# Altium schematic sheet 05-Miscellianous.SchDoc
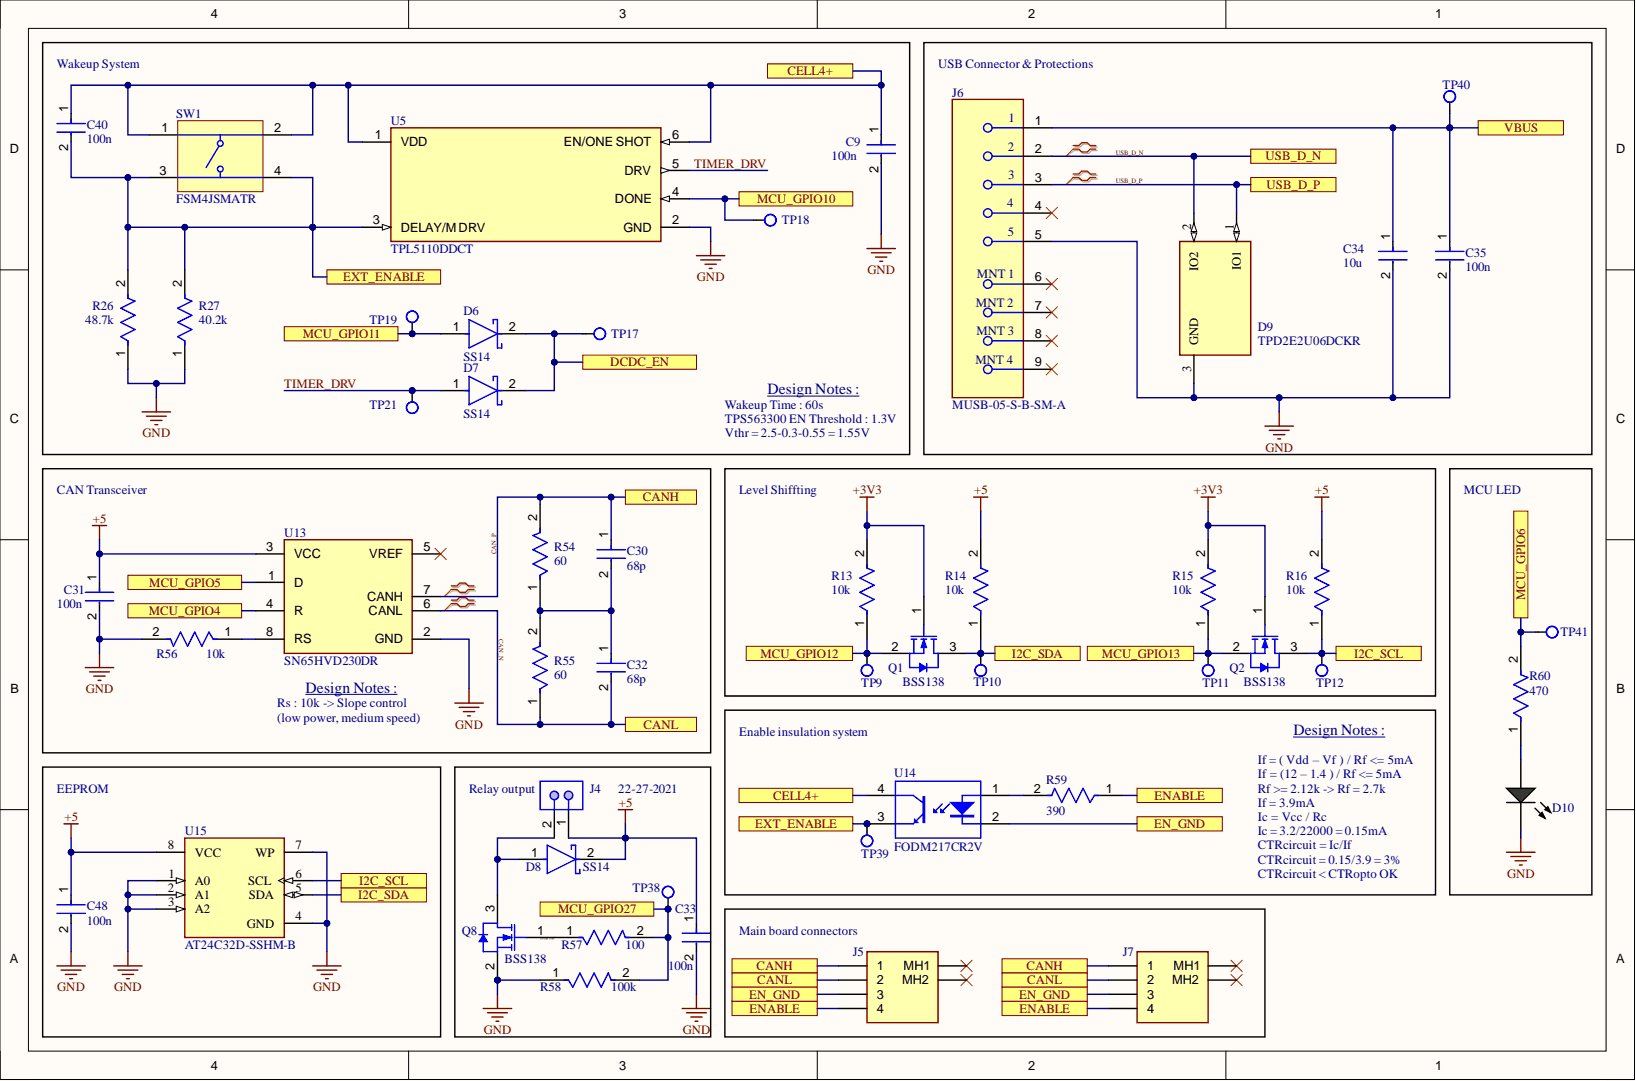
[diagram p0: 05-Miscellianous.SchDoc]

## Parts
C9  100n  [FP-CL21-IPC_A]
C30  68p  [FP-CL21-IPC_A]
C31  100n  [FP-CL21-IPC_A]
C32  68p  [FP-CL21-IPC_A]
C33  100n  [FP-CC0805-0_85-MFG]
C34  10u  [FP-CL21-IPC_A]
C35  100n  [FP-CL21-IPC_A]
C40  100n  [FP-CC0805-0_85-MFG]
C48  100n  [FP-CC0805-0_85-MFG]
D6  SS14  [FP-403AE-MFG]
D7  SS14  [FP-403AE-MFG]
D8  SS14  [FP-403AE-MFG]
D9  TPD2E2U06DCKR  [FP-DCK0003A-IPC_B]
D10  HSMG-C170  [AVAG-HSMX-C170_V]  (value not drawn)
J4  22-27-2021  [MOLX-22-27-2021_V]
J5  1565749-4  [15657494]  (value not drawn)
J6  MUSB-05-S-B-SM-A  [FP-MUSB-05-S-B-SM-A-MFG]
J7  1565749-4  [15657494]  (value not drawn)
Q1  BSS138  [FAIR-SOT23-3_V]
Q2  BSS138  [FAIR-SOT23-3_V]
Q8  BSS138  [FAIR-SOT23-3_V]
R13  10k  [FP-RT0805-MFG]
R14  10k  [FP-RT0805-MFG]
R15  10k  [FP-RT0805-MFG]
R16  10k  [FP-RT0805-MFG]
R26  48.7k  [FP-RT0805-MFG]
R27  40.2k  [FP-RT0805-MFG]
R54  60  [FP-RT0805-MFG]
R55  60  [FP-RT0805-MFG]
R56  10k  [FP-RT0805-MFG]
R57  100  [FP-RT0805-MFG]
R58  100k  [FP-RT0805-MFG]
R59  390  [FP-RT0805-MFG]
R60  470  [FP-RT0805-MFG]
SW1  FSM4JSMATR  [TECO-FSM4JSMATR_V]
TP9  Test point  [Test point-Footprint-1]  (value not drawn)
TP10  Test point  [Test point-Footprint-1]  (value not drawn)
TP11  Test point  [Test point-Footprint-1]  (value not drawn)
TP12  Test point  [Test point-Footprint-1]  (value not drawn)
TP17  Test point  [Test point-Footprint-1]  (value not drawn)
TP18  Test point  [Test point-Footprint-1]  (value not drawn)
TP19  Test point  [Test point-Footprint-1]  (value not drawn)
TP21  Test point  [Test point-Footprint-1]  (value not drawn)
TP38  Test point  [Test point-Footprint-1]  (value not drawn)
TP39  Test point  [Test point-Footprint-1]  (value not drawn)
TP40  Test point  [Test point-Footprint-1]  (value not drawn)
TP41  Test point  [Test point-Footprint-1]  (value not drawn)
U5  TPL5110DDCT  [FP-DDC0006A-MFG]
U13  SN65HVD230DR  [FP-D0008A-MFG]
U14  FODM217CR2V  [SOP127P680X239-4N]
U15  AT24C32D-SSHM-B  [FP-C04-057-SN-MFG]

## Ports
CANH, CANL, CELL4+, DCDC_EN, EN_GND, ENABLE, EXT_ENABLE, I2C_SCL, I2C_SDA, MCU_GPIO4, MCU_GPIO5, MCU_GPIO6, MCU_GPIO10, MCU_GPIO11, MCU_GPIO12, MCU_GPIO13, MCU_GPIO27, USB_D_N, USB_D_P, VBUS

## Nets
+3V3: Q1.1 Q2.1 R13.2 R15.2
+5: C31.1 C33.1 C48.1 D8.2 J4.1 R14.2 R16.2 U13.3(VCC) U15.8(VCC)
GND: C9.2 C31.2 C33.2 C34.2 C35.2 C48.2 D9.3 D10.2 J6.5 Q8.2 R26.1 R27.1 R56.2 R58.1 U5.2(GND) U13.2(GND) U15.1(A0) U15.2(A1) U15.3(A2) U15.4(GND) U15.7(WP)
CAN_N: C32.2 J5.2 J7.2 R55.1 U13.6(CANL) ; port CANL
CAN_P: C30.1 J5.1 J7.1 R54.2 U13.7(CANH) ; port CANH
CELL4+: C9.1 C40.1 SW1.1 SW1.2 U5.1(VDD) U5.6(EN/ONE_SHOT) U14.4 ; port CELL4+
DCDC_EN: D6.2 D7.2 TP17.1 ; port DCDC_EN
EN_GND: J5.3 J7.3 U14.2 ; port EN_GND
ENABLE: J5.4 J7.4 R59.1 ; port ENABLE
EXT_ENABLE: C40.2 R26.2 R27.2 SW1.3 SW1.4 TP39.1 U5.3(DELAY/M_DRV) U14.3 ; port EXT_ENABLE
I2C_SCL: Q2.3 R16.1 TP12.1 U15.6(SCL) ; port I2C_SCL
I2C_SDA: Q1.3 R14.1 TP10.1 U15.5(SDA) ; port I2C_SDA
MCU_GPIO4: U13.4(R) ; port MCU_GPIO4
MCU_GPIO5: U13.1(D) ; port MCU_GPIO5
MCU_GPIO6: R60.2 TP41.1 ; port MCU_GPIO6
MCU_GPIO10: TP18.1 U5.4(DONE) ; port MCU_GPIO10
MCU_GPIO11: D6.1 TP19.1 ; port MCU_GPIO11
MCU_GPIO12: Q1.2 R13.1 TP9.1 ; port MCU_GPIO12
MCU_GPIO13: Q2.2 R15.1 TP11.1 ; port MCU_GPIO13
MCU_GPIO27: R57.2 R58.2 TP38.1 ; port MCU_GPIO27
MOS_RELAY: Q8.1 R57.1
TIMER_DRV: D7.1 TP21.1 U5.5(DRV)
USB_D_N: D9.2 J6.2 ; port USB_D_N
USB_D_P: D9.1 J6.3 ; port USB_D_P
VBUS: C34.1 C35.1 J6.1 TP40.1 ; port VBUS
NetC30_2: C30.2 C32.1 R54.1 R55.2
NetD8_1: D8.1 J4.2 Q8.3
NetD10_1: D10.1 R60.1
NetR56_1: R56.1 U13.8(RS)
NetR59_2: R59.2 U14.1

Not connected: J5 MH1 MH2; J6 4 6 7 8 9; J7 MH1 MH2; U13 5(VREF)

## Library data of the parts
C9 C30-C32 C34 C35 = CMP-13271-002172-1: CL21 Series 0805 10uF 25V ±10% Tolerance X5R Multilayer Ceramic Chip Capacitor; Capacitance: 10uF; Case Code (Imperial): 0805; Case Code (Metric): 2012; Case/Package: 0805; Component Kind: Standard; Depth: 1.25mm; Dielectric: X5R; Height: 0.85mm; Lead Free: Lead Free; Length: 2mm; Library Name: Altium Content Vault; Max Operating Temperature: 85°C; Min Operating Temperature: -55°C; Mount: Surface Mount; Package Quantity: 1; Packaging: Tape and Reel; Radiation Hardening: No; Thickness: 0.037inch; Tolerance: 10%; Voltage Rating: 25V; Width: 0.049inch
  C9 C31 C35: LCSC_Part_#: C49678
  C30 C32: LCSC_Part_#: C961512
  C34: LCSC_Part_#: C88484
C33 C40 C48 = CMP-1036-04410-2: CAP CER 0.1UF 25V X7R 0805; Manufacturer: Yageo; Manufacturer Part Number: CC0805KRX7R8BB104; Capacitance: 100 nF; Material: Ceramic; Tolerance: 0.1; Value: 100 nF; Automotive: No; Category: Cap; Component Kind: Standard; ComponentLink2Description: Datasheet; ComponentLink2URL: http://www.yageo.com/documents/recent/UPY-GPHC_Y5V_6.3V-to-50V_8.pdf; Device Class L1: Passive Components; Device Class L2: Capacitors; Device Class L3: Ceramic Capacitors; Digikey Description: CAP CER 0.1UF 25V X7R 0805; Footprint Url: http://www.yageo.com/exep/pages/download/literatures/UPY-C_GEN_24.pdf; Height: 0.95mm; Ipc Land Pattern Name: CAPC200125X85; LCSC_Part_#: C49678; Lead Free: Yes; Library Name: Altium Content Vault; Mouser Description: Multilayer Ceramic Capacitors MLCC - SMD/SMT 100nF 25V X7R 10%; Octopart: https://octopart.com/cc0805krx7r8bb104-yageo-39467766; Package: CC0805-0.85; RoHS: TRUE; Temperature: -30°C to +85°C; Temperature Characteristic: X7R; Temperature Range High: +85°C; Temperature Range Low: -30°C; Voltage Rating: 25 V
D6-D8 = CMP-2000-04943-2: DIODE SCHOTTKY 40V 1A SMA; Manufacturer: ON Semiconductor; Max Junction Temp: +125°C; Max Surge Forward Current: 40A; Peak Reverse Current: 0.2mA; Standoff Height: 0.05mm; Automotive: No; Category: Diode; Component Kind: Standard; ComponentLink2Description: Datasheet; ComponentLink2URL: https://www.onsemi.com/pub/Collateral/SS19-D.PDF; Device Class L1: Discrete Semiconductors; Device Class L2: Diodes; Device Class L3: Schottky Diodes; Digikey Description: DIODE SCHOTTKY 40V 1A SMA; Forward Current: 1A; Height: 2.5mm; LCSC_Part_#: C2480; Lead Free: Yes; Library Name: Altium Content Vault; Manufacturer Part Number: SS14; Max Repetitive Reverse Voltage: 40V; Mouser Description: Schottky Diodes & Rectifiers SMA 40V 1.0A; Octopart: https://octopart.com/ss14-on+semiconductor-29205033; Package: 403AE; RoHS: TRUE; Temperature: -65°C to +125°C; Temperature Range High: +125°C; Temperature Range Low: -65°C
D9 = CMP-04985-000012-1: Q100 TO CATALOG SPIN OF TPD2E2U0; Component Kind: Standard; LCSC_Part_#: C1855726; Library Name: Altium Content Vault; RoHS Compliant: Yes
D10 = CMP-2000-05062-1: Chip LED, Green, 2.2 V, -40 to 85 degC, 2-Pin SMD, Pb-Free, Tape and Reel; Manufacturer: Avago; Bulb Size: 1.25mmx1.4mm; Dominant Wavelength: 572nm; External Depth: 0.8mm; External Length / Height: 2mm; External Width: 1.25mm; Forward Current If: 20mA; Forward Voltage: 2.2V; Forward Voltage VF Max: 2.6V; LED Type: Standard; Lens Shape: Rectangular; Light Area Height: 1mm; Luminous Intensity: 15mcd; Luminous Intensity Min: 4mcd; No. of Pins: 2; Opto Mounting Orientation: Top; Peak Wavelength: 570nm; PIV Max: 5V; SVHC: NoSVHC(16-Jun-2014); Viewing Angle: 170deg; Component Kind: Standard; Datasheet URL: https://datasheet.ciiva.com/29280/180495-da-01-en-chip-led-hsmg-c170-gelb-gruen-29280971.pdf; Datasheet Version: Oct-08; Dominant Wavelength Typ: 572nm; Forward Current If Max: 20mA; LCSC_Part_#: C84256; LED Colour: Green; LED Mounting: SMD; Library Name: Altium Content Vault; Luminous Intensity Typ: 15mcd; Manufacturer URL: http://www.avagotech.com/; Mounting Type: SMD; MSL: MSL2A-4weeks; Operating Temperature Max: 85degC; Operating Temperature Min: -30degC; Operating Temperature Range: -30degCto+85degC; Opto Case Style: SMD; Package Description: 2-Pin Surface Mount Device, Body 2 x 1.25 mm, Height 0.8 mm; Package Reference: HSMX-C170; Packaging: CutTape; Peak Forward Current: 20mA; Storage Temperature Max: 85degC; Storage Temperature Min: -40degC; Voltage Vf Typ: 2.2V; Wavelength Typ: 570nm
J4 = CMP-2000-05537-1: Male Header, Pitch 2.54 mm, 1 x 2 Position, Height 11.7 mm, Tail Length 3.56 mm, 0 to 75 degC, RoHS, Bulk; Manufacturer: Molex; PartNumber: 0022272021; Current - Max: 4A; No. of Positions: 2; No. of Rows: 1; Package Version: Rev. BC, 02/2012; Pitch: 2.54mm; Voltage - Max: 250V; Component Kind: Standard; Contact material: Tinplatedbrass; Current rating: 4A; Datasheet URL: http://www.molex.com/pdm_docs/sd/022272021_sd.pdf; Datasheet Version: Rev. F, 06/2009; Housing material: Nylon(UL94V-0); LCSC_Part_#: C293316; Library Name: Altium Content Vault; Manufacturer URL: http://www.molex.com/; Mounting Technology: ThroughHole; Operating temperature: 0°Cto+75°C; Orientation: Vertical; Package Description: 2-Leads Header, Body 5.08 x 5.8 mm, Pitch 2.54 mm; Package Reference: 22-27-2021; Voltage rating: 250V
J5 J7 = CMP-001-00083-3: Automotive Connectors 025 4POS CAP ASY SELECTIVE-TIN; Manufacturer_Name: TE Connectivity; Geometry.Height: 12.7mm; Component Kind: Standard; Datasheet Link: http://www.te.com/commerce/DocumentDelivery/DDEController?Action=srchrtrv&DocNm=1565749&DocType=Customer+Drawing&DocLang=Japanese; Design Item ID: 1565749-4; Manufacturer_Part_Number: 1565749-4; Mouser Part Number: 571-1565749-4; Mouser Price/Stock: https://www.mouser.co.uk/ProductDetail/TE-Connectivity-AMP/1565749-4?qs=kSbAZawwBnzokATJjcWq2w%3D%3D; Source: 1565749-4.SchLib; Library Name: Clement Verda
J6 = CMP-02757-000001-1: CONN RCPT USB2.0 MINI B SMD R/A; Connector Type: USB; Gender: Receptacle; Max Voltage Rating (AC): 30V; Number of Contacts: 5; Number of Ports: 1; Pitch: 0.8mm; Component Kind: Standard; Contact Material: Bronze; Contact Plating: Gold; Depth: 9.2mm; Housing Material: Thermoplastic; Insulation Material: Thermoplastic; LCSC_Part_#: C5362859; Length: 9.9mm; Library Name: Altium Content Vault; Max Operating Temperature: 85°C; Min Operating Temperature: -50°C; Mount: Surface Mount; Number of Terminals: 5; Orientation: Right Angle; Package Quantity: 1; Packaging: Tray; Radiation Hardening: No; REACH SVHC: No SVHC; Termination: Solder; USB Standard: USB 2.0
Q1 Q2 Q8 = CMP-013-00021-4: N-Channel Logic Level Enhancement Mode Field Effect Transistor, 220 mA, 50 V, -55 to 150 degC, 3-Pin SOT-23, RoHS, Tape and Reel; Manufacturer: Fairchild; Elements: 1; Input Capacitance: 27pF; Nominal Dual Supply Voltage: 50V; Nominal Vgs: 1.3V; Package Version: Rev. 12, 03/2016; Power: 360mW; Rds On Max: 3.5Ohm; Resistance: 0.7Ohm; Typical Rise Time: 9ns; Case/Package: TO-236-3; Component Kind: Standard; Datasheet URL: https://www.fairchildsemi.com/datasheets/BS/BSS138.pdf; Datasheet Version: Rev. C, 10/2005; LCSC_Part_#: C112239; Max Operating Temperature: 150°C; Min Operating Temperature: -55°C; Mounting Technology: SM; Package Description: 3-Pin Small Outline Transistor, Body 2.92 x 1.325 mm, Pitch 0.95 mm; Package Quantity: 1; Package Reference: SOT23-3; Packaging: TapeandReel; Pins: 3; RoHS Compliant: True; Library Name: Clement Verda
R13-R16 = CMP-03412-005354-1: RES SMD 4.7K OHM 0.5% 1/8W 0805; Manufacturer: Yageo; Manufacturer Part Number: RT0805DRE074K7L; Power Rating: 0.125W; Resistance: 4.7KΩ; Temperature Coefficient: 50 ppm/°C; Tolerance: 0.01; Value: 4.7KΩ; Voltage Rating: 150V; Automotive: No; Category: Res; Component Kind: Standard; ComponentLink2Description: Datasheet; ComponentLink2URL: http://www.yageo.com/documents/recent/PYu-RT_1-to-0.01_RoHS_L_9.pdf; Composition: Thin Film; Device Class L1: Passive Components; Device Class L2: Resistors; Device Class L3: Chip SMD Resistors; Digikey Description: RES SMD 4.7K OHM 0.5% 1/8W 0805; Footprint Url: http://www.yageo.com/exep/pages/download/literatures/PYu-R_Mount_10.pdf; Height: 0.6mm; Ipc Land Pattern Name: RESC200125X50; LCSC_Part_#: C17414; Lead Free: Yes; Library Name: Altium Content Vault; Octopart: https://octopart.com/rt0805dre074k7l-yageo-1242027; Package: RT0805; RoHS: TRUE; Series: RT; Temperature: -55°C to +155°C; Temperature Range High: +155°C; Temperature Range Low: -55°C
R26 R27 = CMP-03412-005354-1: RES SMD 470 OHM 0.5% 1/8W 0805; Manufacturer: Yageo; Manufacturer Part Number: RT0805DRE074K7L; Power Rating: 0.125W; Resistance: 1kΩ; Temperature Coefficient: 50 ppm/°C; Tolerance: 0.01; Value: 1kΩ; Voltage Rating: 150V; Automotive: No; Category: Res; Component Kind: Standard; ComponentLink2Description: Datasheet; ComponentLink2URL: http://www.yageo.com/documents/recent/PYu-RT_1-to-0.01_RoHS_L_9.pdf; Composition: Thin Film; Device Class L1: Passive Components; Device Class L2: Resistors; Device Class L3: Chip SMD Resistors; Digikey Description: RES SMD 4.7K OHM 0.5% 1/8W 0805; Footprint Url: http://www.yageo.com/exep/pages/download/literatures/PYu-R_Mount_10.pdf; Height: 0.6mm; Ipc Land Pattern Name: RESC200125X50; Lead Free: Yes; Library Name: Altium Content Vault; Octopart: https://octopart.com/rt0805dre074k7l-yageo-1242027; Package: RT0805; RoHS: TRUE; Series: RT; Temperature: -55°C to +155°C; Temperature Range High: +155°C; Temperature Range Low: -55°C
R54-R56 R58 = CMP-03412-005354-1: RES SMD 100K OHM 1% 1/8W 0805; Manufacturer: Yageo; Manufacturer Part Number: RT0805DRE074K7L; Power Rating: 0.125W; Resistance: 100KΩ; Temperature Coefficient: 50 ppm/°C; Tolerance: 0.01; Value: 100KΩ; Voltage Rating: 150V; Automotive: No; Category: Res; Component Kind: Standard; ComponentLink2Description: Datasheet; ComponentLink2URL: http://www.yageo.com/documents/recent/PYu-RT_1-to-0.01_RoHS_L_9.pdf; Composition: Thin Film; Device Class L1: Passive Components; Device Class L2: Resistors; Device Class L3: Chip SMD Resistors; Digikey Description: RES SMD 4.7K OHM 0.5% 1/8W 0805; Footprint Url: http://www.yageo.com/exep/pages/download/literatures/PYu-R_Mount_10.pdf; Height: 0.6mm; Ipc Land Pattern Name: RESC200125X50; Lead Free: Yes; Library Name: Altium Content Vault; Octopart: https://octopart.com/rt0805dre074k7l-yageo-1242027; Package: RT0805; RoHS: TRUE; Series: RT; Temperature: -55°C to +155°C; Temperature Range High: +155°C; Temperature Range Low: -55°C
  R54 R55: LCSC_Part_#: C17757
  R58: LCSC_Part_#: C149504
R57 = CMP-03412-005354-1: RES SMD 100 OHM 1% 1/8W 0805; Manufacturer: Yageo; Manufacturer Part Number: RT0805DRE074K7L; Power Rating: 0.125W; Resistance: 100Ω; Temperature Coefficient: 50 ppm/°C; Tolerance: 0.01; Value: 100Ω; Voltage Rating: 150V; Automotive: No; Category: Res; Component Kind: Standard; ComponentLink2Description: Datasheet; ComponentLink2URL: http://www.yageo.com/documents/recent/PYu-RT_1-to-0.01_RoHS_L_9.pdf; Composition: Thin Film; Device Class L1: Passive Components; Device Class L2: Resistors; Device Class L3: Chip SMD Resistors; Digikey Description: RES SMD 4.7K OHM 0.5% 1/8W 0805; Footprint Url: http://www.yageo.com/exep/pages/download/literatures/PYu-R_Mount_10.pdf; Height: 0.6mm; Ipc Land Pattern Name: RESC200125X50; LCSC_Part_#: C17408; Lead Free: Yes; Library Name: Altium Content Vault; Octopart: https://octopart.com/rt0805dre074k7l-yageo-1242027; Package: RT0805; RoHS: TRUE; Series: RT; Temperature: -55°C to +155°C; Temperature Range High: +155°C; Temperature Range Low: -55°C
R59 R60 = CMP-03412-005354-1: RES SMD 470 OHM 0.5% 1/8W 0805; Manufacturer: Yageo; Manufacturer Part Number: RT0805DRE074K7L; Power Rating: 0.125W; Resistance: 4.7KΩ; Temperature Coefficient: 50 ppm/°C; Tolerance: 0.01; Value: 4.7KΩ; Voltage Rating: 150V; Automotive: No; Category: Res; Component Kind: Standard; ComponentLink2Description: Datasheet; ComponentLink2URL: http://www.yageo.com/documents/recent/PYu-RT_1-to-0.01_RoHS_L_9.pdf; Composition: Thin Film; Device Class L1: Passive Components; Device Class L2: Resistors; Device Class L3: Chip SMD Resistors; Digikey Description: RES SMD 4.7K OHM 0.5% 1/8W 0805; Footprint Url: http://www.yageo.com/exep/pages/download/literatures/PYu-R_Mount_10.pdf; Height: 0.6mm; Ipc Land Pattern Name: RESC200125X50; Lead Free: Yes; Library Name: Altium Content Vault; Octopart: https://octopart.com/rt0805dre074k7l-yageo-1242027; Package: RT0805; RoHS: TRUE; Series: RT; Temperature: -55°C to +155°C; Temperature Range High: +155°C; Temperature Range Low: -55°C
  R59: LCSC_Part_#: C17655
  R60: LCSC_Part_#: C865503
SW1 = CMP-2000-07486-1: Micro Miniature Push Button Switch FSM JSM, 50 mA, -35 to 85 degC, 4-Pin SMD, RoHS, Tape and Reel; Manufacturer: TE Connectivity; Actuator Length: 0.055inch; Actuator Material: Polyamide; Capacitance: 0f; Case\/Package: SMD/SMT; Contact Current Rating: 50mA; Contact Resistance: 100mOhm; Electrical Life: 100000Cycles; Illumination Type: Illuminated; Max Voltage Rating (DC): 12V; Operating Force: 160gf; Switch Travel: 0.25mm; Throw Configuration: SPST; Voltage Rating (DC): 24V; Component Kind: Standard; Contact Material: CopperAlloy; Contact Plating: Silver; Current Rating: 50mA; Datasheet URL: http://www.te.com/commerce/DocumentDelivery/DDEController?Action=showdoc&DocId=Customer+Drawing%7F2-1437565-7%7FV%7Fpdf%7FEnglish%7FENG_CD_2-1437565-7_V.pdf%7F4-1437565-2; Datasheet Version: Rev. V, 02/2015; Library Name: Altium Content Vault; Manufacturer URL: http://www.te.com/; Max Operating Temperature: 85degC; Mechanical Life: 100000Cycles; Min Operating Temperature: -35degC; Mount: SurfaceMount; Mounting Technology: SurfaceMount; Orientation: Straight; Package Description: 4-Pin Surface Mount Device, Body 6 x 6 mm, Pitch 4.5 mm; Package Quantity: 1200; Package Reference: FSM4JSMATR; Packaging: TapeandReel; REACH SVHC: Unknown; RoHSCompliant: true; Termination: GullWing
TP9-TP12 TP17-TP19 TP21 TP38-TP41 = CMP-002-00075-1: Component Kind: Standard; Description: test point; Library Name: Clement Verda
U5 = CMP-04858-000011-1: IC OSC PROG TIMER TSOT23-6; Manufacturer: Texas Instruments; Max Junction Temp: +150°C; Max Supply Voltage: 5.5V; Min Supply Voltage: 1.8V; Nominal Supply Current: 0.035uA; Number Of Timers/Counters: 1; Standoff Height: 0mm; Automotive: No; Category: IC; Component Kind: Standard; ComponentLink2Description: Datasheet; ComponentLink2URL: http://www.ti.com/lit/ds/symlink/tpl5110.pdf; Device Class L1: Integrated Circuits (ICs); Device Class L2: Clock and Timing; Device Class L3: Timers and Oscillators; Digikey Description: IC OSC PROG TIMER TSOT23-6; Height: 1.1mm; LCSC_Part_#: C125830; Lead Free: Yes; Library Name: Altium Content Vault; Manufacturer Part Number: TPL5110DDCT; Mouser Description: Timers & Support Products Ultra Lw Pwr Timer; Octopart: https://octopart.com/tpl5110ddct-texas+instruments-52670271; Package: DDC0006A; RoHS: TRUE; Temperature: -40°C to +105°C; Temperature Range High: +105°C; Temperature Range Low: -40°C
U13 = CMP-015-00009-2: Interface Circuit, 1-Trnsvr, BICMOS, PDSO8; Nominal Supply Voltage: 3.3V; Case/Package: SOP; Component Kind: Standard; LCSC_Part_#: C12084; Max Operating Temperature: 85°C; Min Operating Temperature: -40°C; Pins: 8; RoHS Compliant: Yes; Library Name: Clement Verda
U14 = CMP-00026-00001-1: Transistor Output Optocoupler, 1-Element, 3750V Isolation; Component Kind: Standard; LCSC_Part_#: C891189; RoHS Compliant: Yes; Library Name: Clement Verda
U15 = CMP-06313-000164-1: IC EEPROM 32KBIT I2C 1MHZ 8SOIC; Access Time: 550ns; Density: 32Kb; Interface: Serial; Max Supply Voltage: 5.5V; Min Supply Voltage: 1.7V; Nominal Supply Current: 3mA; Number of Words: 4096; Operating Supply Voltage: 5V; Organization: 4KX8; Write Protection: YES; Access Time-Max: 550ns; Case/Package: SOIC; Component Kind: Standard; Frequency: 1MHz; Height: 1.5mm; Length: 5.05mm; Library Name: Altium Content Vault; Max Frequency: 1MHz; Max Operating Temperature: 85°C; Memory Size: 32Kb; Memory Type: EEPROM; Min Operating Temperature: -40°C; Mount: Surface Mount; Number of Pins: 8; Package Quantity: 100; Packaging: Bulk; Radiation Hardening: No; Reach SVHC Compliant: Yes; RoHS Compliant: Yes; Series: -; Supply Current: 3mA; Width: 3.99mm
